# Altium schematic sheet P06_LED, Config, & Button.SchDoc
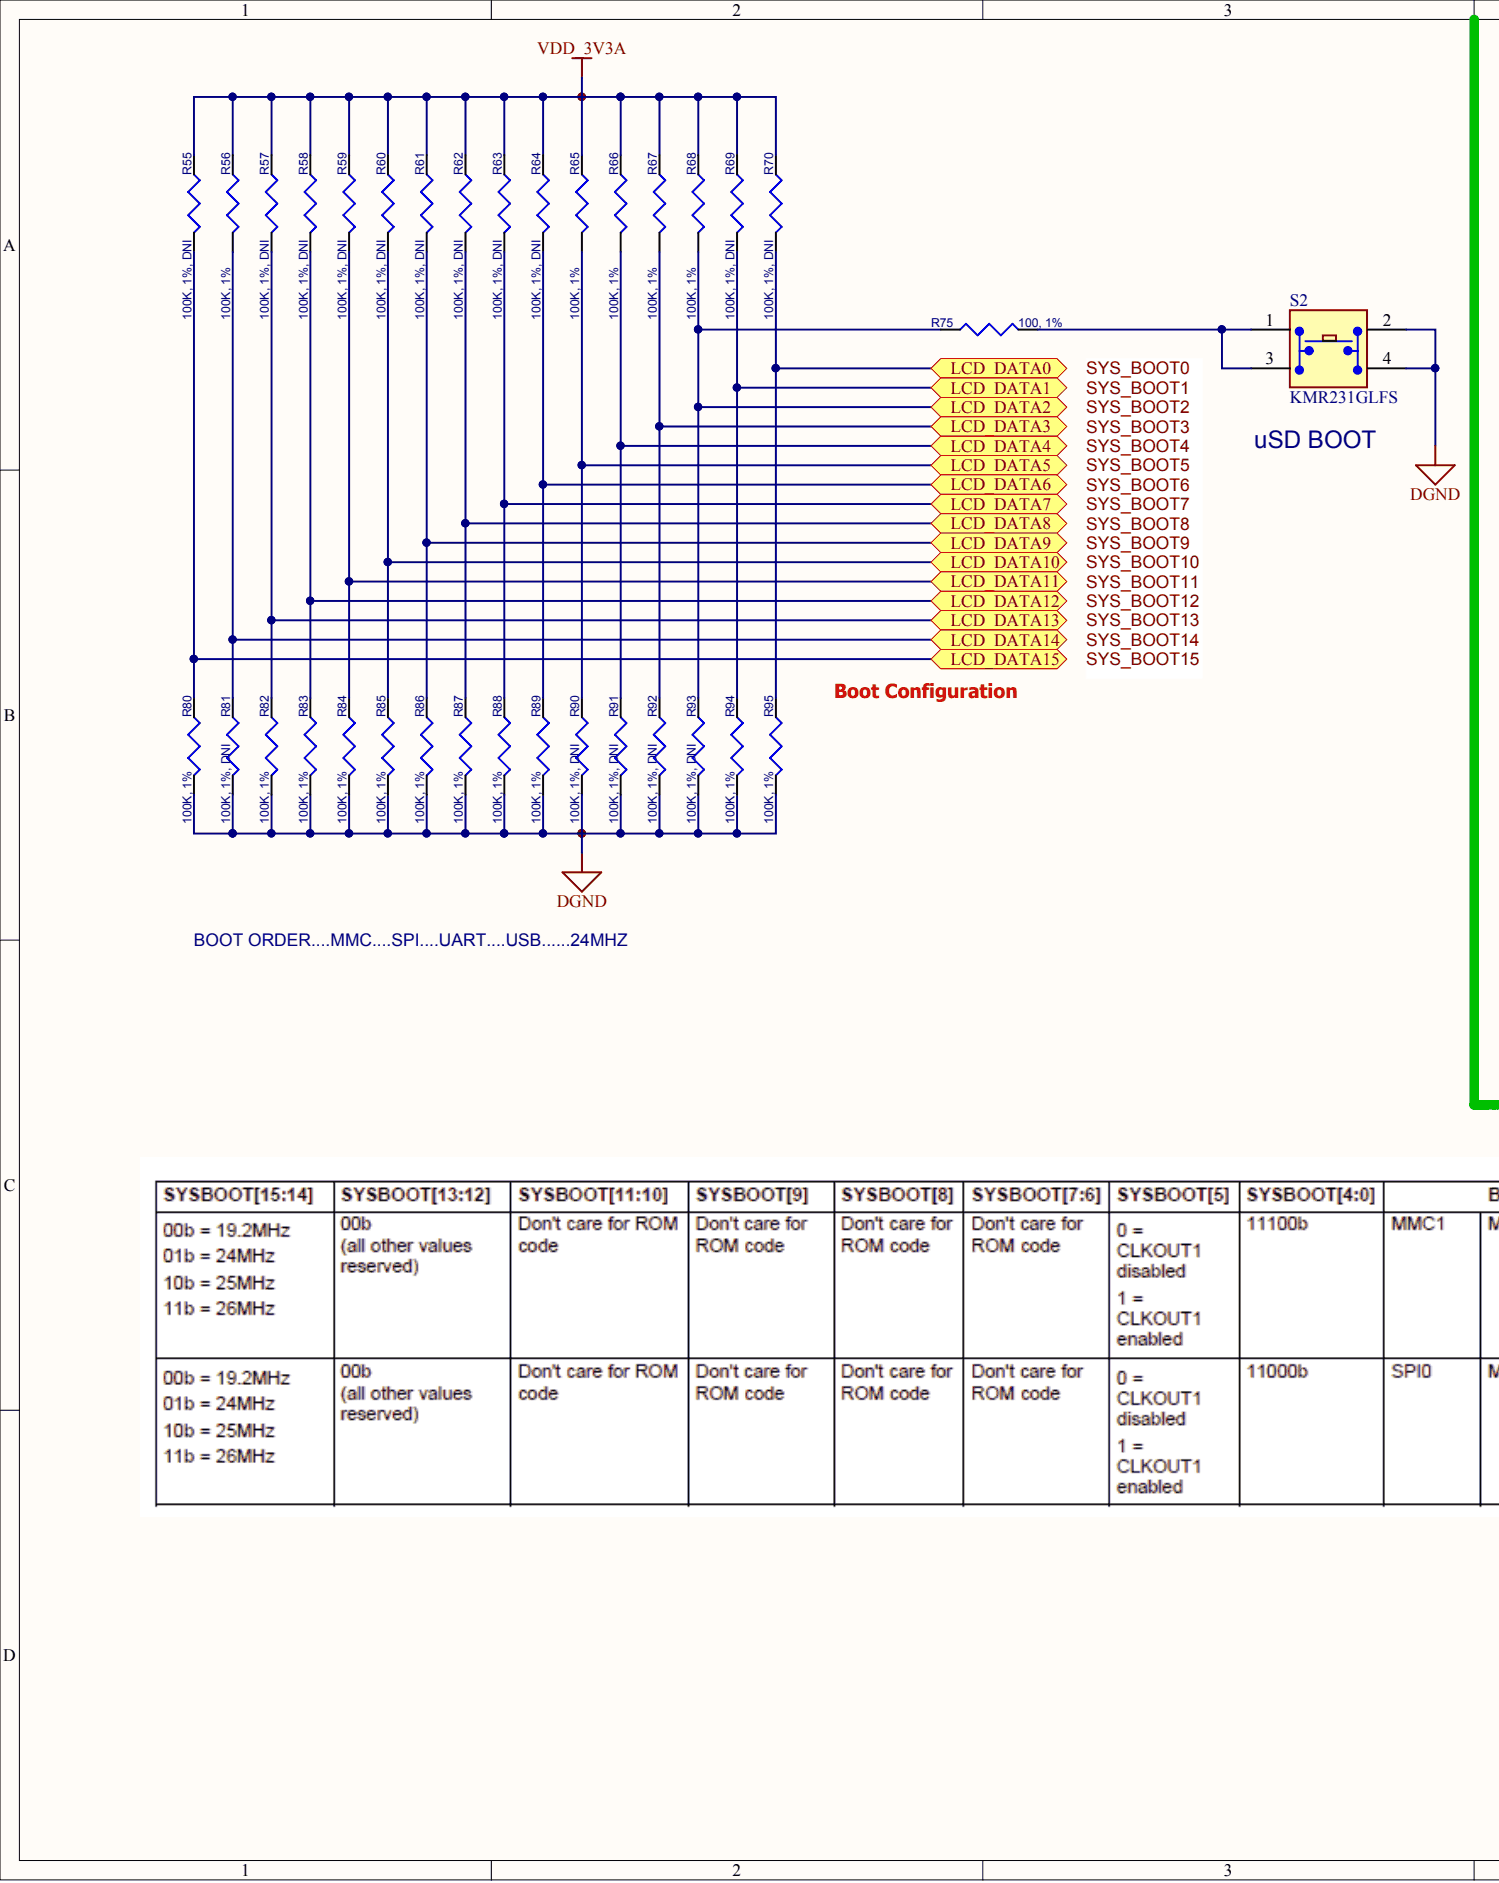
[diagram p0: P06_LED, Config, & Button.SchDoc, left]
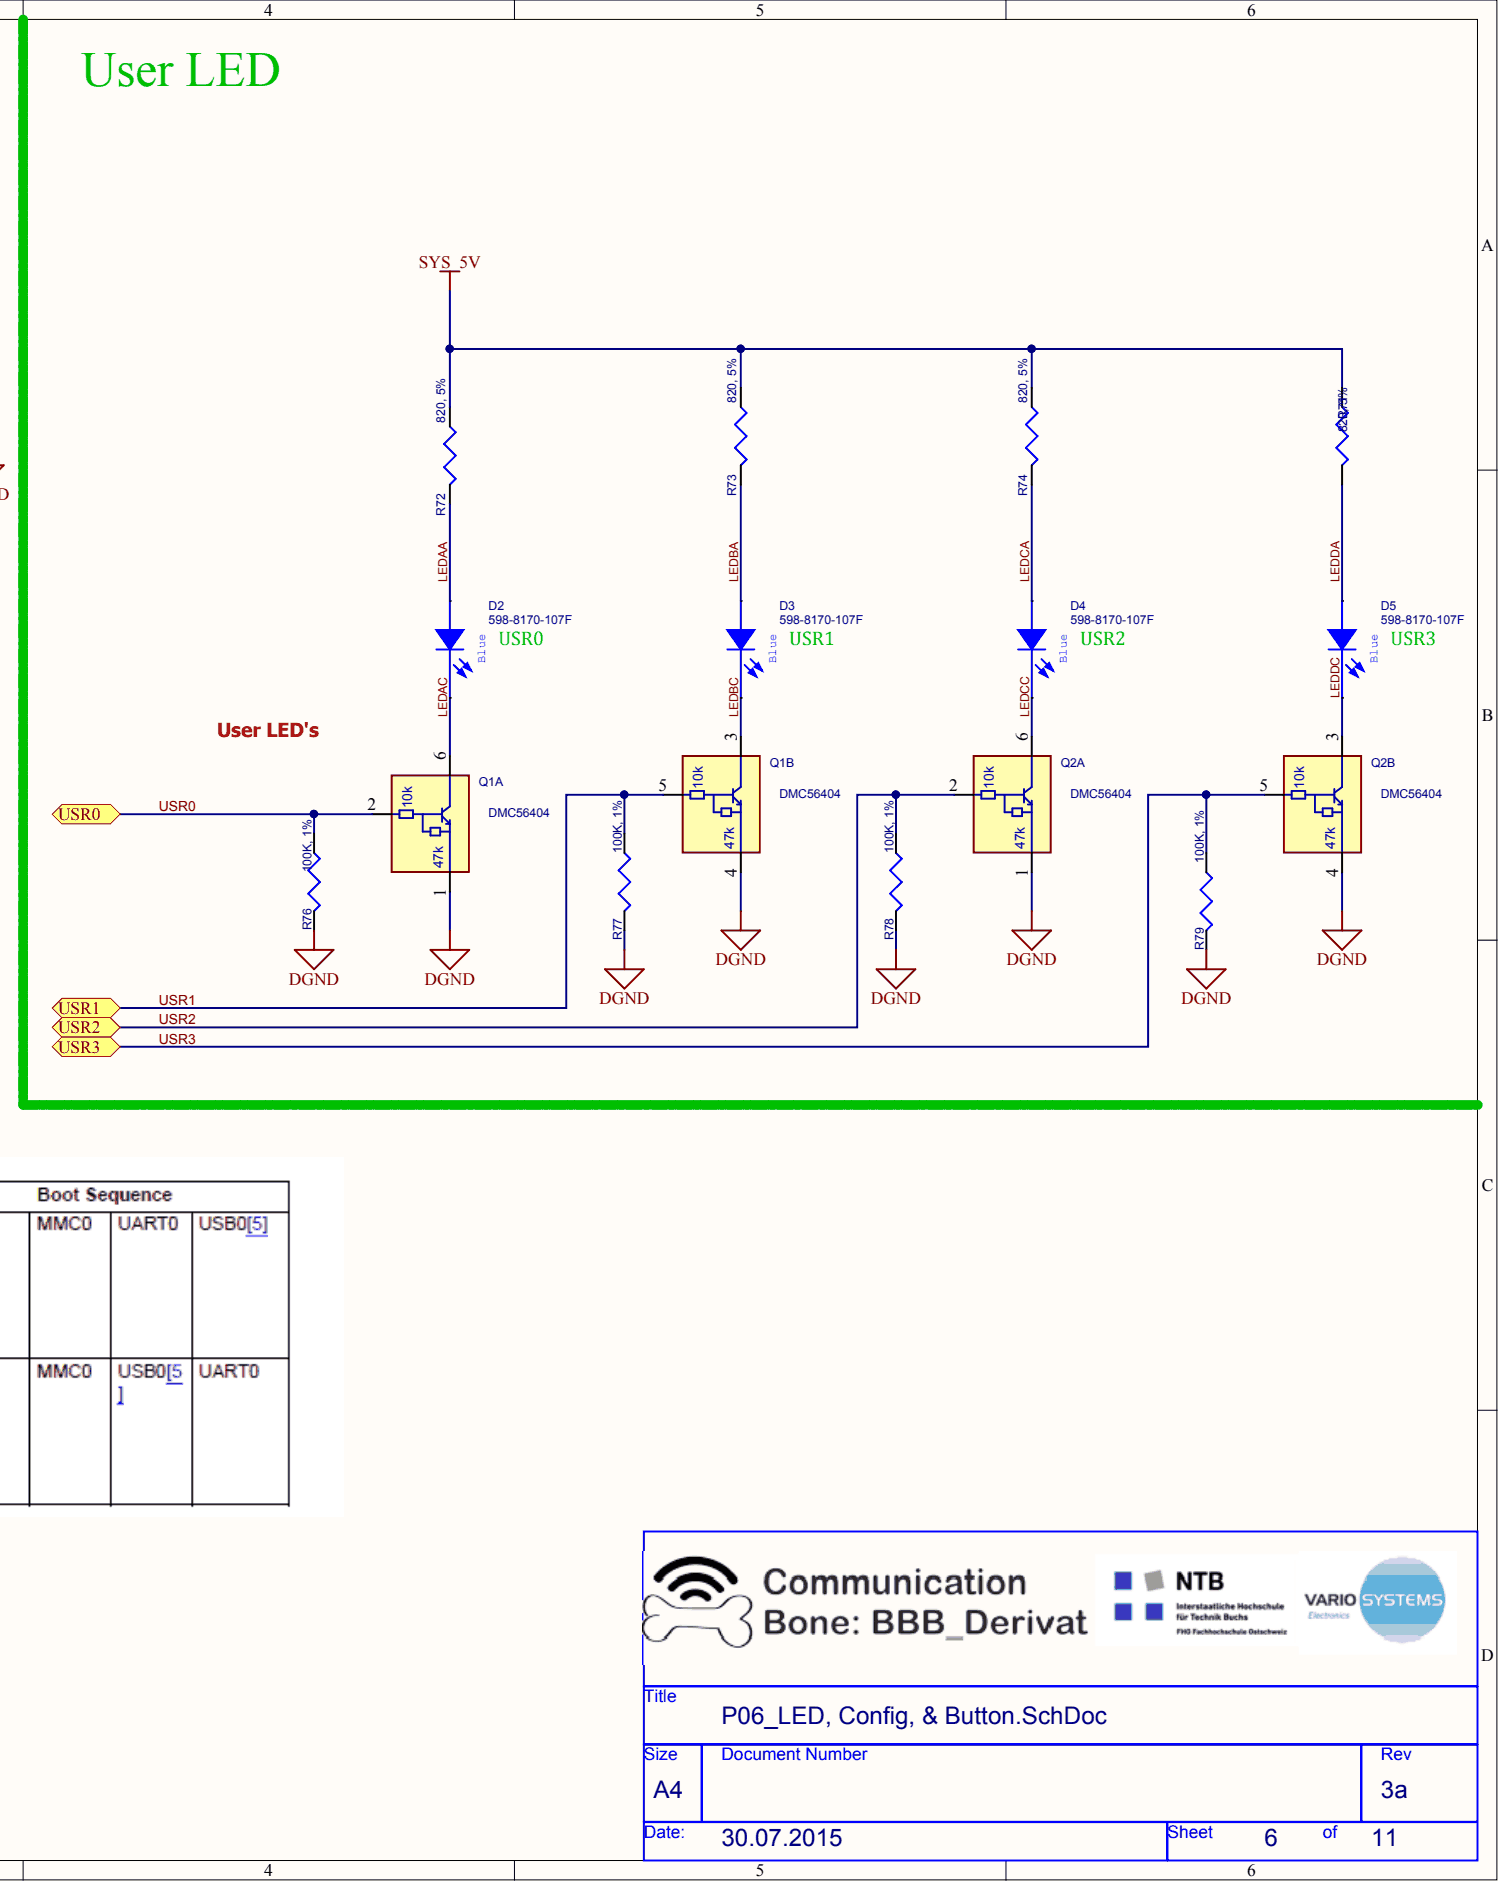
[diagram p1: P06_LED, Config, & Button.SchDoc, right]

## Parts
D2  598-8170-107F  [LED_0603]
D3  598-8170-107F  [LED_0603]
D4  598-8170-107F  [LED_0603]
D5  598-8170-107F  [LED_0603]
Q1  DMC56404  Resistor - Base (R1) (Ohms): 10k  Resistor - Emitter Base (R2) (Ohms): 47k  [SMini6-F3-B]  (parts A,B)
Q2  DMC56404  Resistor - Base (R1) (Ohms): 10k  Resistor - Emitter Base (R2) (Ohms): 47k  [SMini6-F3-B]  (parts A,B)
R55  100K, 1%, DNI  [402]
R56  100K, 1%  [402]
R57  100K, 1%, DNI  [402]
R58  100K, 1%, DNI  [402]
R59  100K, 1%, DNI  [402]
R60  100K, 1%, DNI  [402]
R61  100K, 1%, DNI  [402]
R62  100K, 1%, DNI  [402]
R63  100K, 1%, DNI  [402]
R64  100K, 1%, DNI  [402]
R65  100K, 1%  [402]
R66  100K, 1%  [402]
R67  100K, 1%  [402]
R68  100K, 1%  [402]
R69  100K, 1%, DNI  [402]
R70  100K, 1%, DNI  [402]
R71  820, 5%  [402]
R72  820, 5%  [402]
R73  820, 5%  [402]
R74  820, 5%  [402]
R75  100, 1%  [402]
R76  100K, 1%  [402]
R77  100K, 1%  [402]
R78  100K, 1%  [402]
R79  100K, 1%  [402]
R80  100K, 1%  [402]
R81  100K, 1%, DNI  [402]
R82  100K, 1%  [402]
R83  100K, 1%  [402]
R84  100K, 1%  [402]
R85  100K, 1%  [402]
R86  100K, 1%  [402]
R87  100K, 1%  [402]
R88  100K, 1%  [402]
R89  100K, 1%  [402]
R90  100K, 1%, DNI  [402]
R91  100K, 1%, DNI  [402]
R92  100K, 1%, DNI  [402]
R93  100K, 1%, DNI  [402]
R94  100K, 1%  [402]
R95  100K, 1%  [402]
S2  KMR231GLFS  [SW4SMD_63X161LS]

## Ports
LCD_DATA0, LCD_DATA1, LCD_DATA2, LCD_DATA3, LCD_DATA4, LCD_DATA5, LCD_DATA6, LCD_DATA7, LCD_DATA8, LCD_DATA9, LCD_DATA10, LCD_DATA11, LCD_DATA12, LCD_DATA13, LCD_DATA14, LCD_DATA15, USR0, USR1, USR2, USR3

## Nets
DGND: Q1.1 Q1.4 Q2.1 Q2.4 R76.2 R77.2 R78.2 R79.2 R80.2 R81.2 R82.2 R83.2 R84.2 R85.2 R86.2 R87.2 R88.2 R89.2 R90.2 R91.2 R92.2 R93.2 R94.2 R95.2 S2.2 S2.4
SYS_5V: R71.1 R72.1 R73.1 R74.1
VDD_3V3A: R55.1 R56.1 R57.1 R58.1 R59.1 R60.1 R61.1 R62.1 R63.1 R64.1 R65.1 R66.1 R67.1 R68.1 R69.1 R70.1
LCD_DATA0: R70.2 R95.1 ; port LCD_DATA0
LCD_DATA1: R69.2 R94.1 ; port LCD_DATA1
LCD_DATA2: R68.2 R75.1 R93.1 ; port LCD_DATA2
LCD_DATA3: R67.2 R92.1 ; port LCD_DATA3
LCD_DATA4: R66.2 R91.1 ; port LCD_DATA4
LCD_DATA5: R65.2 R90.1 ; port LCD_DATA5
LCD_DATA6: R64.2 R89.1 ; port LCD_DATA6
LCD_DATA7: R63.2 R88.1 ; port LCD_DATA7
LCD_DATA8: R62.2 R87.1 ; port LCD_DATA8
LCD_DATA9: R61.2 R86.1 ; port LCD_DATA9
LCD_DATA10: R60.2 R85.1 ; port LCD_DATA10
LCD_DATA11: R59.2 R84.1 ; port LCD_DATA11
LCD_DATA12: R58.2 R83.1 ; port LCD_DATA12
LCD_DATA13: R57.2 R82.1 ; port LCD_DATA13
LCD_DATA14: R56.2 R81.1 ; port LCD_DATA14
LCD_DATA15: R55.2 R80.1 ; port LCD_DATA15
LEDAA: D2.2 R72.2
LEDAC: D2.1 Q1.6
LEDBA: D3.2 R73.2
LEDBC: D3.1 Q1.3
LEDCA: D4.2 R74.2
LEDCC: D4.1 Q2.6
LEDDA: D5.2 R71.2
LEDDC: D5.1 Q2.3
USR0: Q1.2 R76.1 ; port USR0
USR1: Q1.5 R77.1 ; port USR1
USR2: Q2.2 R78.1 ; port USR2
USR3: Q2.5 R79.1 ; port USR3
NetR75_2: R75.2 S2.1 S2.3

## Library data of the parts
D2-D5 = LED_LTST-C150GKT: LED GREEN CLEAR 0805 SMD; Component Kind: Standard; Mounting Type: Surface Mount; Package / Case: 0603; Packaging: Digi-Reel®; Supplier 1: Digi-Key; Supplier Part Number 1: 160-1647-6-ND; Library Name: BBB_Derivat.SchLib
Q1 Q2 = RN1907: TRANS PREBIASED DUAL NPN SOT363; Manufacturer: Toshiba; Manufacturer Part Number: DDC114YU-7-F; ATLStockNo1: 2130.0137; ATLStockNo2: 2130.01; Current - Collector (Ic) (Max): 100mA; Current - Collector Cutoff (Max): 500nA; DC Current Gain (hFE) (Min) @ Ic, Vce: 68 @ 10mA, 5V; Frequency - Transition: 250MHz; Order# 2: BCR135S; Power - Max: 200mW; Transistor Type: 2 NPN - Pre-Biased (Dual); Vce Saturation (Max) @ Ib, Ic: 300mV @ 250µA, 5mA; Voltage - Collector Emitter Breakdown (Max): 50V; Category: Discrete Semiconductor Products; Component Kind: Standard; ComponentLink1Description: http://www.diodes.com/datasheets/ds30345.pdf; ComponentLink1URL: http://www.diodes.com/datasheets/ds30345.pdf; Mounting Type: Surface Mount; Package / Case: 6-TSSOP, SC-88, SOT-363; Packaging: Digi-Reel®; Pricing 1: 1=0.46, 10=0.334, 25=0.2596, 100=0.1966, 250=0.13896, 500=0.11124, 1000=0.08532 (USD); RoHS: RoHS Compliant; Stock 1: 11411; Supplier 1: Digi-Key; Supplier Device Package: SOT-363; Supplier Part Number 1: DDC114YU-FDIDKR-ND; Library Name: BBB_Derivat.SchLib
R55 R57-R64 R69 R70 R81 R90-R93 = RES_100K_1/16W_1%_0402: RES 100K OHM 1/16W 1% 0402 SMD; Manufacturer: Stackpole Electronics Inc; Manufacturer Part Number: RMCF0402FT100K; Power (Watts): 0.063W, 1/16W; Temperature Coefficient: ±100ppm/°C; Category: Resistors; Component Kind: Standard; ComponentLink1Description: http://www.seielect.com/Catalog/SEI-RMCF_RMCP.pdf; ComponentLink1URL: http://www.seielect.com/Catalog/SEI-RMCF_RMCP.pdf; Composition: Thick Film; Features: -; Height: 0.016" (0.40mm); Number of Terminations: 2; Package / Case: 0402 (1005 Metric); Packaging: Digi-Reel®; Pricing 1: 1=0.04, 10=0.025, 50=0.0138, 100=0.0105, 250=0.0078, 500=0.00576, 1000=0.00384, 2500=0.00324, 5000=0.0027 (USD); Resistance (Ohms): 100k; RoHS: RoHS Compliant; Size / Dimension: 0.039" L x 0.020" W (1.00mm x 0.50mm); Stock 1: 851196; Supplier 1: Digi-Key; Supplier Device Package: 0402; Supplier Part Number 1: RMCF0402FT100KDKR-ND; Tolerance: ±1%; Library Name: BBB_Derivat.SchLib
R56 R65-R68 R76-R80 R82-R89 R94 R95 = RES_100K_1/16W_1%_0402: RES 100K OHM 1/16W 1% 0402 SMD; Manufacturer: Stackpole Electronics Inc; Manufacturer Part Number: RMCF0402FT100K; VS Part Number: 241847; Power (Watts): 0.063W, 1/16W; Temperature Coefficient: ±100ppm/°C; Category: Resistors; Component Kind: Standard; ComponentLink1Description: http://www.seielect.com/Catalog/SEI-RMCF_RMCP.pdf; ComponentLink1URL: http://www.seielect.com/Catalog/SEI-RMCF_RMCP.pdf; Composition: Thick Film; Features: -; Height: 0.016" (0.40mm); Number of Terminations: 2; Package / Case: 0402 (1005 Metric); Packaging: Digi-Reel®; Pricing 1: 1=0.04, 10=0.025, 50=0.0138, 100=0.0105, 250=0.0078, 500=0.00576, 1000=0.00384, 2500=0.00324, 5000=0.0027 (USD); Resistance (Ohms): 100k; RoHS: RoHS Compliant; Size / Dimension: 0.039" L x 0.020" W (1.00mm x 0.50mm); Stock 1: 851196; Supplier 1: Digi-Key; Supplier Device Package: 0402; Supplier Part Number 1: RMCF0402FT100KDKR-ND; Tolerance: ±1%; Library Name: BBB_Derivat.SchLib
R71-R74 = RES_820_1/16W_5%_0402: RES 820 OHM 1/16W 5% 0402 SMD; Manufacturer: Yageo; Manufacturer Part Number: RC0402JR-07820RL; VS Part Number: 245844; Power (Watts): 0.063W, 1/16W; Resistance (Ohms): 820; Temperature Coefficient: ±100ppm/°C; Category: Resistors; Component Kind: Standard; ComponentLink1Description: http://www.yageo.com/documents/recent/PYu-RC0402_51_RoHS_L_6.pdf; ComponentLink1URL: http://www.yageo.com/documents/recent/PYu-RC0402_51_RoHS_L_6.pdf; Composition: Thick Film; Features: -; Height: 0.016" (0.40mm); Number of Terminations: 2; Package / Case: 0402 (1005 Metric); Packaging: Digi-Reel®; Pricing 1: 1=0.1, 10=0.012, 25=0.0092, 100=0.0055, 250=0.00476, 500=0.00336, 1000=0.00237, 2500=0.00206, 5000=0.00178 (USD); RoHS: RoHS Compliant; Size / Dimension: 0.039" L x 0.020" W (1.00mm x 0.50mm); Stock 1: 7437; Supplier 1: Digi-Key; Supplier Device Package: 0402; Supplier Part Number 1: 311-820JRDKR-ND; Tolerance: ±5%; Library Name: BBB_Derivat.SchLib
R75 = RES_100_1/10_1%_0402: RES 100 OHM 1/10W 1% 0402 SMD; Manufacturer: Panasonic Electronic Components; Manufacturer Part Number: ERJ-2RKF1000X; PART#: Rohm MCR03EZPFX1000; VS Part Number: 226222; Power (Watts): 0.1W, 1/10W; Resistance (Ohms): 100; Temperature Coefficient: ±100ppm/°C; Category: Resistors; Component Kind: Standard; ComponentLink1Description: http://industrial.panasonic.com/www-cgi/jvcr13pz.cgi?E+PZ+3+AOA0002+ERJ2RKF1000X+7+WW; ComponentLink1URL: http://industrial.panasonic.com/www-cgi/jvcr13pz.cgi?E+PZ+3+AOA0002+ERJ2RKF1000X+7+WW; Composition: Thick Film; Features: -; Height: 0.016" (0.40mm); Number of Terminations: 2; Package / Case: 0402 (1005 Metric); Packaging: Digi-Reel®; Pricing 1: 1=0.1, 50=0.0242, 100=0.0184, 250=0.01364, 500=0.01008, 1000=0.00672, 5000=0.00473 (USD); RoHS: RoHS; Size / Dimension: 0.039" L x 0.020" W (1.00mm x 0.50mm); Stock 1: 2375521; Supplier 1: Digi-Key; Supplier Device Package: 0402; Supplier Part Number 1: P100LDKR-ND; Library Name: BBB_Derivat.SchLib
S2 = KMR2: Microminiature Tact Switch for SMT; Manufacturer: C&K Components; Actuator Height off PCB, from Pins: 1.90mm; Actuator Orientation: Top Actuated; Actuator Type: Standard; Circuit: SPST-NO; Contact Rating @ Voltage: 0.05A @ 32VDC; Illumination: Non-Illuminated; Mechanical Life: 150,000 Cycles; Operating Force: 300gf; Outline: 4.60mm x 2.80mm; Switch Function: Off-Mom; Switch Travel: 0.25mm; Actuator Material: -; Category: Switches; Component Kind: Standard; ComponentLink1Description: http://www.ck-components.com/index.php?module=media&action=Display&cmpref=14414&lang=en&width=&height=&format=&alt=; ComponentLink1URL: http://www.ck-components.com/index.php?module=media&action=Display&cmpref=14414&lang=en&width=&height=&format=&alt=; Features: Grounding Pin; Illumination Type, Color: -; Illumination Voltage (Nominal): -; Ingress Protection: -; Manufacturer Part Number: KMR231GLFS; Mounting Type: Surface Mount; Operating Temperature: -40°C ~ 85°C; Packaging: Digi-Reel®; Pricing 1: 1=0.44, 10=0.416, 25=0.3744, 50=0.3326, 100=0.3188, 250=0.29108, 500=0.2772, 1000=0.22869 (USD); RoHS: RoHS Compliant; Stock 1: 32289; Supplier 1: Digi-Key; Supplier Part Number 1: 401-1429-6-ND; Termination Style: Gull Wing; Library Name: BBB_Derivat.SchLib
